# Altium schematic sheet 01 HK4530 V2.1  POWER.SchDoc
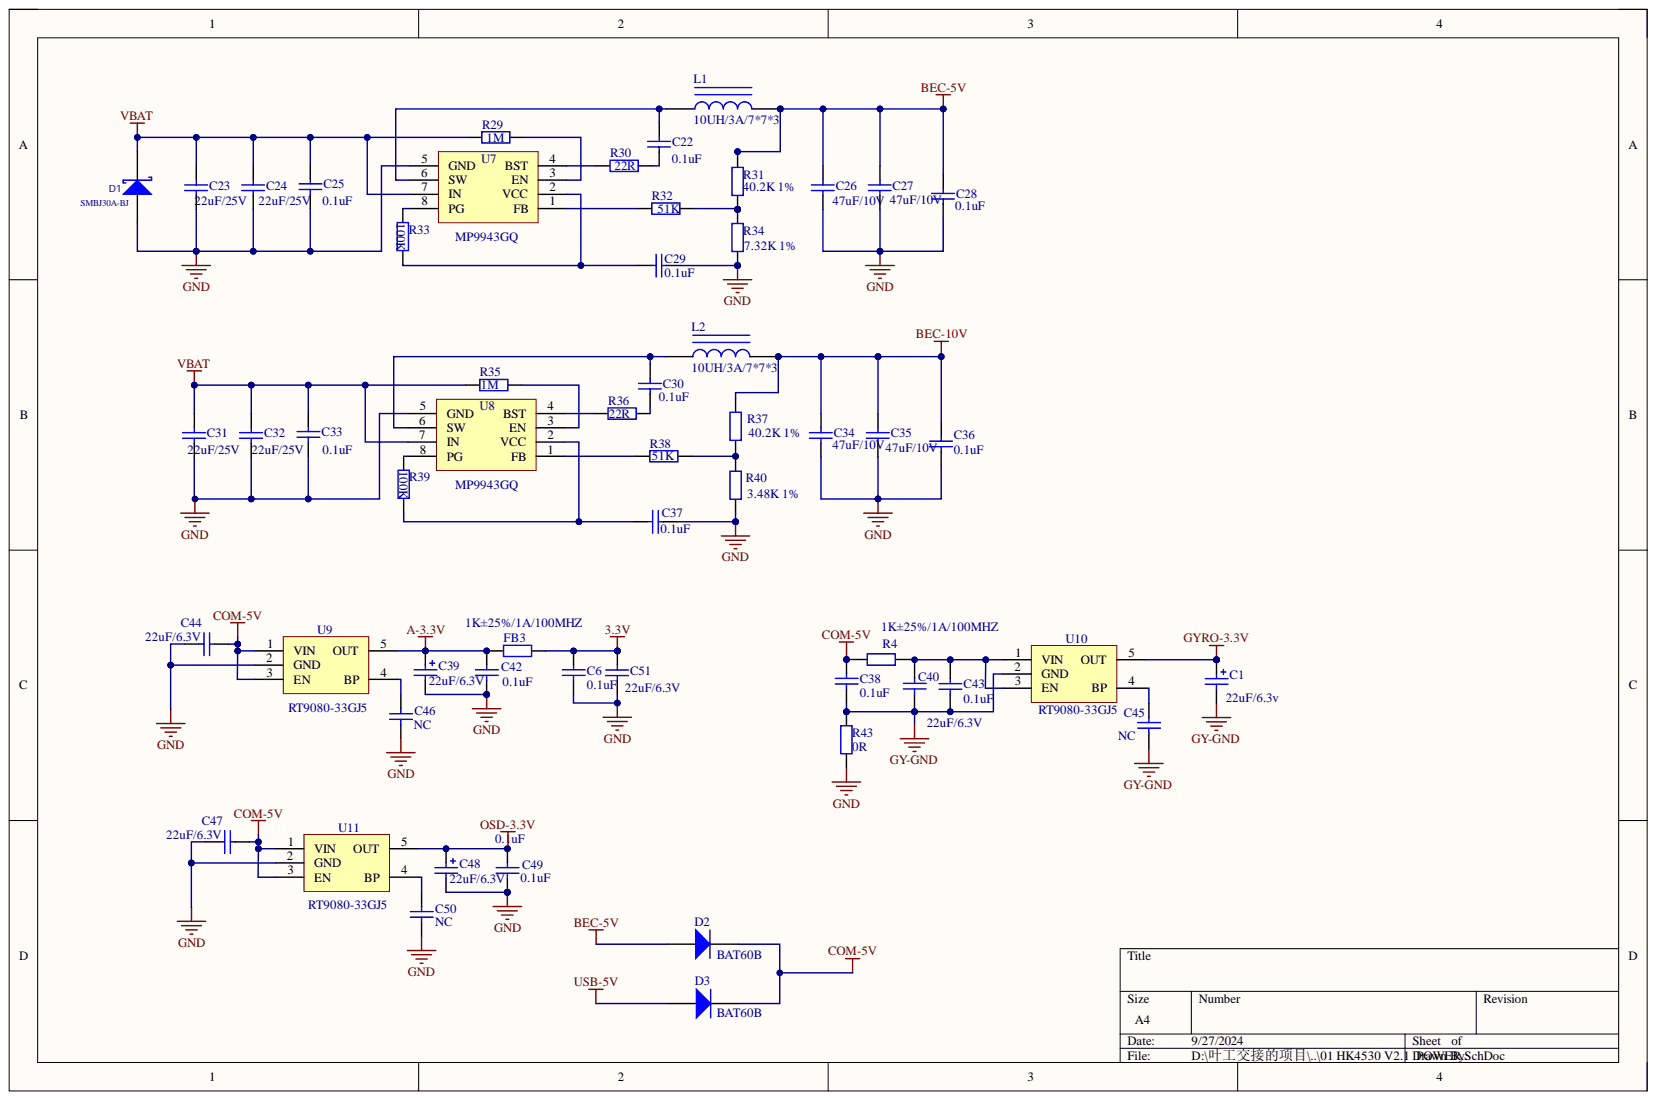
[diagram p0: 01 HK4530 V2.1  POWER.SchDoc]

## Parts
C1  22uF/6.3v  [C0805-TAN]
C6  0.1uF  [C0201]
C22  0.1uF  [C0201]
C23  22uF/25V  [C0805]
C24  22uF/25V  [C0805]
C25  0.1uF  [C0402]
C26  47uF/10V  [C0805]
C27  47uF/10V  [C0805]
C28  0.1uF  [C0201]
C29  0.1uF  [C0201]
C30  0.1uF  [C0201]
C31  22uF/25V  [C0805]
C32  22uF/25V  [C0805]
C33  0.1uF  [C0402]
C34  47uF/10V  [C0805]
C35  47uF/10V  [C0805]
C36  0.1uF  [C0201]
C37  0.1uF  [C0201]
C38  0.1uF  [C0201]
C39  22uF/6.3V  [C0603-D]
C40  22uF/6.3V  [C0603-D]
C42  0.1uF  [C0201]
C43  0.1uF  [C0201]
C44  22uF/6.3V  [C0603-D]
C45  NC  [C0201]
C46  NC  [C0201]
C47  22uF/6.3V  [C0603-D]
C48  22uF/6.3V  [C0603-D]
C49  0.1uF  [C0201]
C50  NC  [C0201]
C51  22uF/6.3V  [C0603-D]
D1  SMBJ30A-BJ  [SMBF26A]
D2  BAT60B  [BAT60B]
D3  BAT60B  [BAT60B]
FB3  1K±25%/1A/100MHZ  [FB]
L1  10UH/3A/7*7*3  [L7*7-Y]
L2  10UH/3A/7*7*3  [L7*7-Y]
R4  1K±25%/1A/100MHZ  [FB]
R29  1M  [R0201]
R30  22R  [R0201]
R31  40.2K 1%  value 40.2K  [R0201]
R32  51K  [R0201]
R33  100K  [R0201]
R34  7.32K 1%  [R0201]
R35  1M  [R0201]
R36  22R  [R0201]
R37  40.2K 1%  value 40.2K  [R0201]
R38  51K  [R0201]
R39  100K  [R0201]
R40  3.48K 1%  [R0201]
R43  0R  [R0201]
U7  MP9943GQ  [MP9943]
U8  MP9943GQ  [MP9943]
U9  RT9080-33GJ5  [RT9193]
U10  RT9080-33GJ5  [RT9193]
U11  RT9080-33GJ5  [RT9193]

## Nets
3.3V: C6.1 C51.2 FB3.1
A-3.3V: C39.1 C42.1 FB3.2 U9.5(OUT)
BEC-5V: C26.2 C27.2 C28.2 D2.1 L1.2 R31.1
BEC-10V: C34.2 C35.2 C36.2 L2.2 R37.1
COM-5V: C38.1 C44.1 C47.1 D2.2 D3.2 R4.2 U9.1(VIN) U9.3(EN) U11.1(VIN) U11.3(EN)
GND: C6.2 C23.1 C24.1 C25.2 C26.1 C27.1 C28.1 C29.1 C31.1 C32.1 C33.2 C34.1 C35.1 C36.1 C37.1 C39.2 C42.2 C44.2 C46.2 C47.2 C48.2 C49.2 C50.2 C51.1 D1.1 R34.2 R40.2 R43.1 U7.5(GND) U8.5(GND) U9.2(GND) U11.2(GND)
GY-GND: C1.2 C38.2 C40.2 C43.2 C45.2 R43.2 U10.2(GND)
GYRO-3.3V: C1.1 U10.5(OUT)
OSD-3.3V: C48.1 C49.1 U11.5(OUT)
USB-5V: D3.1
VBAT: C23.2 C24.2 C25.1 C31.2 C32.2 C33.1 D1.2 R29.1 R35.1 U7.7(IN) U8.7(IN)
NetC22_1: C22.1 R30.2
NetC22_2: C22.2 L1.1 U7.6(SW)
NetC29_2: C29.2 R33.1 U7.2(VCC)
NetC30_1: C30.1 R36.2
NetC30_2: C30.2 L2.1 U8.6(SW)
NetC37_2: C37.2 R39.1 U8.2(VCC)
NetC40_1: C40.1 C43.1 R4.1 U10.1(VIN) U10.3(EN)
NetC45_1: C45.1 U10.4(BP)
NetC46_1: C46.1 U9.4(BP)
NetC50_1: C50.1 U11.4(BP)
NetR29_2: R29.2 U7.3(EN)
NetR30_1: R30.1 U7.4(BST)
NetR31_2: R31.2 R32.1 R34.1
NetR32_2: R32.2 U7.1(FB)
NetR33_2: R33.2 U7.8(PG)
NetR35_2: R35.2 U8.3(EN)
NetR36_1: R36.1 U8.4(BST)
NetR37_2: R37.2 R38.1 R40.1
NetR38_2: R38.2 U8.1(FB)
NetR39_2: R39.2 U8.8(PG)

## Library data of the parts
C1 C39 C48 = DC1206: Capacitor; Value: 22uF; Component Kind: Standard; Library Name: Integrated_Library_gr.IntLib
C6 C22 C28-C30 C36-C38 C42 C43 C45 C49 C50 = C0201: Capacitor; Component Kind: Standard
  C6: Library Name: Miscellaneous Connectors.IntLib; Value: 0.1uF
  C22 C28-C30 C36-C38 C42 C43 C49: Library Name: Integrated_Library_gr.IntLib; Value: 0.1uF
  C45 C50: Library Name: Integrated_Library_gr.IntLib; Value: NC
C23 C24 C31 C32 = C0805: Capacitor; Value: 22uF; Component Kind: Standard; Library Name: Integrated_Library_gr.IntLib
C25 C33 = C0402: Capacitor; Component Kind: Standard; Library Name: Integrated_Library_gr.IntLib; Value: 0.1uF
C26 C27 C34 C35 = C0805: Capacitor; Value: 47uF; Component Kind: Standard; Library Name: Integrated_Library_gr.IntLib
C40 C44 C47 = C0603: Capacitor; Value: 22uF; Component Kind: Standard; Library Name: Integrated_Library_gr.IntLib
C46 = C0201: Capacitor; Value: 10nF; Component Kind: Standard; Library Name: Integrated_Library_gr.IntLib
C51 = C0603: Capacitor; Component Kind: Standard; Library Name: Miscellaneous Connectors.IntLib; Value: 22uF/6.3V
D1 = SMBF26A: Diode; PCB DECAL: SMA; Component Kind: Standard
D2 D3 = BAT60B: Diode; Component Kind: Standard; Library Name: Integrated_Library_gr.IntLib
FB3 R4 = FB: Component Kind: Standard; Description: FB; Library Name: Integrated_Library_gr.IntLib
L1 L2 = L-7*7: Inductor; Component Kind: Standard; Library Name: Integrated_Library_gr.IntLib
R29-R33 R35-R39 R43 = R0201: Resistor; Component Kind: Standard; Library Name: Integrated_Library_gr.IntLib
  R29 R35: Value: 1M
  R30 R36: Value: 22R
  R32 R38: Value: 51K
  R33 R39: Value: 100K
R34 = R0201: Resistor; Value: 3.83K; Component Kind: Standard; Library Name: Integrated_Library_gr.IntLib
R40 = R0201: Resistor; Value: 7.32K; Component Kind: Standard; Library Name: Integrated_Library_gr.IntLib
U7 U8 = MU9943: IC; Component Kind: Standard; Library Name: Integrated_Library_gr.IntLib
U9-U11 = RT9193: IC; Component Kind: Standard; Library Name: Integrated_Library_gr.IntLib
